# Altium schematic sheet Therm Conditioning.SchDoc
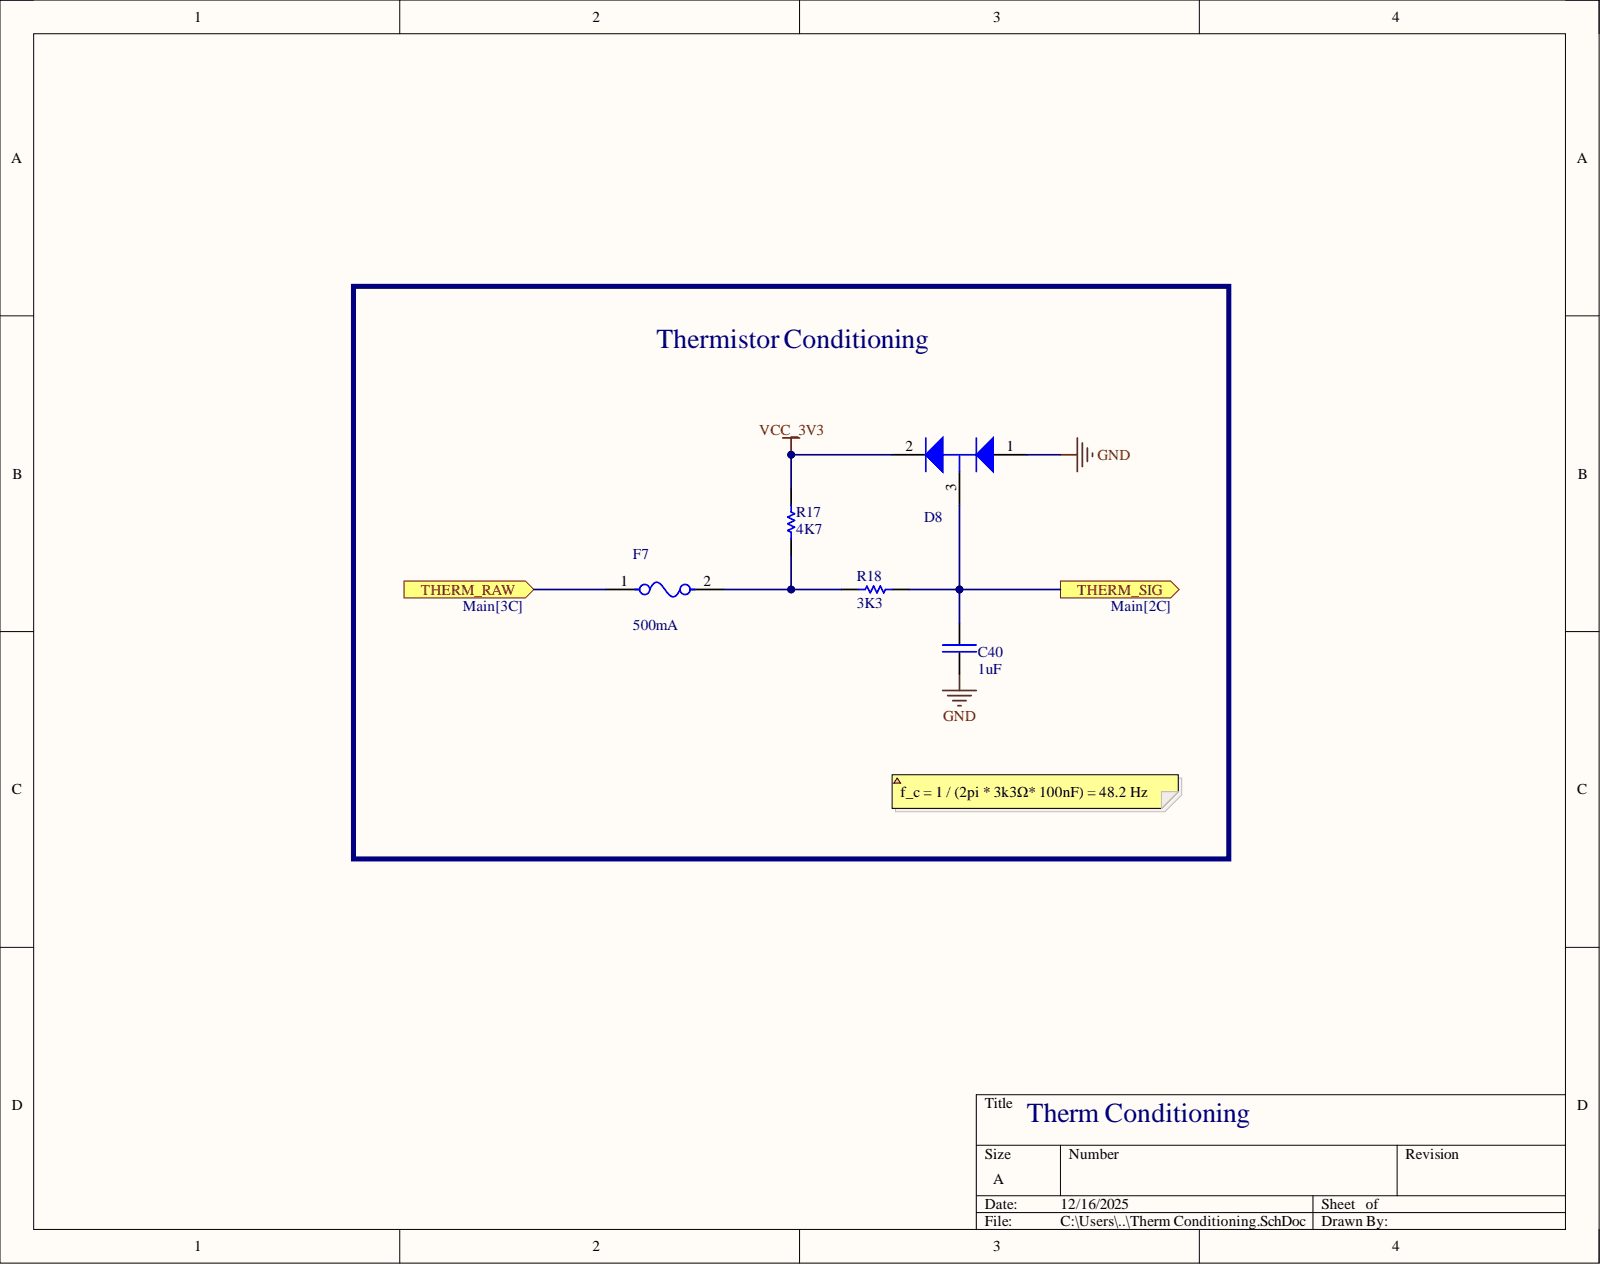
[diagram p0: Therm Conditioning.SchDoc]

## Parts
C40  1uF  [CAP_SM0603_YAGEOCC2_N]
D8  MMBD452LT1G  [ONSC-SOT-23-3-318-08_V]  (value not drawn)
F7  500mA  [FP-0466-MFG]
R17  4K7  [RES_SM0603_YAGEORC_N]
R18  3K3  [RES_SM0603_YAGEORC_N]

## Ports
THERM_RAW, THERM_SIG

## Nets
GND: C40.2 D8.1
VCC_3V3: D8.2 R17.2
THERM_RAW: F7.1 ; port THERM_RAW
THERM_SIG: C40.1 D8.3 R18.2 ; port THERM_SIG
NetF7_2: F7.2 R17.1 R18.1

## Library data of the parts
C40 = CC0603KRX7R9BB105: CAP 1uF SM0603 X7R 50V YAGEO CC2; Manufacturer Part Number: CC0603KRX7R9BB183; Tolerance (%): 10; Voltage Rating (Volts): 50; Category: Capacitor; Component Kind: Standard; ComponentLink1Description: Manufacturer Website; ComponentLink1URL: http://www.yageo.com; Library Name: ResistorCapsInductors.IntLib; Manufacturer: Yageo; Package: 0603; Supplier 1: Farnell; Supplier 2: Digi-Key; Supplier Part Number 1: 3019743; Value: 1uF
D8 = MMBD452LT1G: 30V SCHOTTKY; Manufacturer: On Semiconductor; Configuration: Dual; Packing: Tape and Reel; Component Kind: Standard; ComponentLink1Description: Manufacturer URL; ComponentLink1URL: http://www.onsemi.com/; ComponentLink2Description: Datasheet; ComponentLink2URL: http://www.onsemi.cn/pub_link/Collateral/MMBD452LT1-D.PDF; ComponentLink3Description: Package Specification; ComponentLink3URL: http://www.onsemi.cn/pub_link/Collateral/318-08.PDF; DatasheetVersion: Rev. 4; Library Name: DiodesAndFuses.IntLib; Manufacturer Part Number: MMBD452LT1G; Mounting Technology: Surface Mount; PackageDescription: 3-Pin Small Outline Transistor, Body 2.92 x 1.3 mm, Pitch 0.95 mm; PackageReference: SOT-23-3-318-08; PackageVersion: Rev. AP, 11/2009; PartNumber: MMBD452LT1G; RoHS: TRUE; Supplier 1: DigiKey; Supplier Part Number 1: MMBD452LT1GOSCT-ND
F7 = 0466.500NR: 500mA Fuse; Manufacturer: Littelfuse Inc; Fuse Blow Speed: Fast; Fuse Current: 0.5A; Fuse I2T: 0.006A2Sec; Fuse Interrupt Ac Current: 50A; Fuse Voltage Drop: 158.47mV; Max Fuse Ac Voltage: 63V; Resistance: 0.248Ω; Value: 0.248Ω; Automotive: No; Category: Fuse; Component Kind: Standard; ComponentLink2Description: Datasheet; ComponentLink2URL: https://www.littelfuse.com/~/media/electronics/datasheets/fuses/littelfuse_fuse_466_datasheet.pdf.pdf; Device Class L1: Circuit Protection; Device Class L2: Fuses; Device Class L3: unset; Digikey Description: FUSE BOARD MOUNT 500MA 63VAC/VDC; Fuse Interrupt Dc Current: 50A; Height: 0.66mm; Lead Free: Yes; Library Name: DiodesAndFuses.IntLib; Manufacturer Part Number: 0466.500NR; Max Fuse Dc Voltage: 63V; Mouser Description: SMD Fuse 0.5 A Fast-Blow, 466 1206; Octopart: https://octopart.com/0466.500nr-littelfuse-566004; Package: 0466; RoHS: TRUE; Temperature: -55°C to +90°C; Temperature Range High: +90°C; Temperature Range Low: -55°C; Voltage Rating: 63V
R17 = RC0603FR-074K7L: RES 4K7 SM0603 YAGEO RC_L; Power (Watts): 0.1; Resistance (Ohms): 4700; Tolerance (%): 1; Category: Resistor; Component Kind: Standard; ComponentLink1Description: Manufacturer Website; ComponentLink1URL: http://www.yageo.com; Library Name: ResistorCapsInductors.IntLib; Manufacturer: Yageo; Manufacturer Part Number: RC0603FR-074K7L; Package: 0603; Supplier 1: Farnell; Supplier 2: Digi-Key; Supplier Part Number 1: 9238565; Supplier Part Number 2: 311-4.70KHRCT-ND; Value: 4K7
R18 = RC0603FR-073K3L: RES 3K3 SM0603 YAGEO RC_L; Power (Watts): 0.1; Resistance (Ohms): 3300; Tolerance (%): 1; Category: Resistor; Component Kind: Standard; ComponentLink1Description: Manufacturer Website; ComponentLink1URL: http://www.yageo.com; Library Name: ResistorCapsInductors.IntLib; Manufacturer: Yageo; Manufacturer Part Number: RC0603FR-073K3L; Package: 0603; Supplier 1: Farnell; Supplier 2: Digi-Key; Supplier Part Number 1: 9238549; Supplier Part Number 2: 311-3.30KHRCT-ND; Value: 3K3
